# Altium schematic sheet MBoard_MotorControl.SchDoc
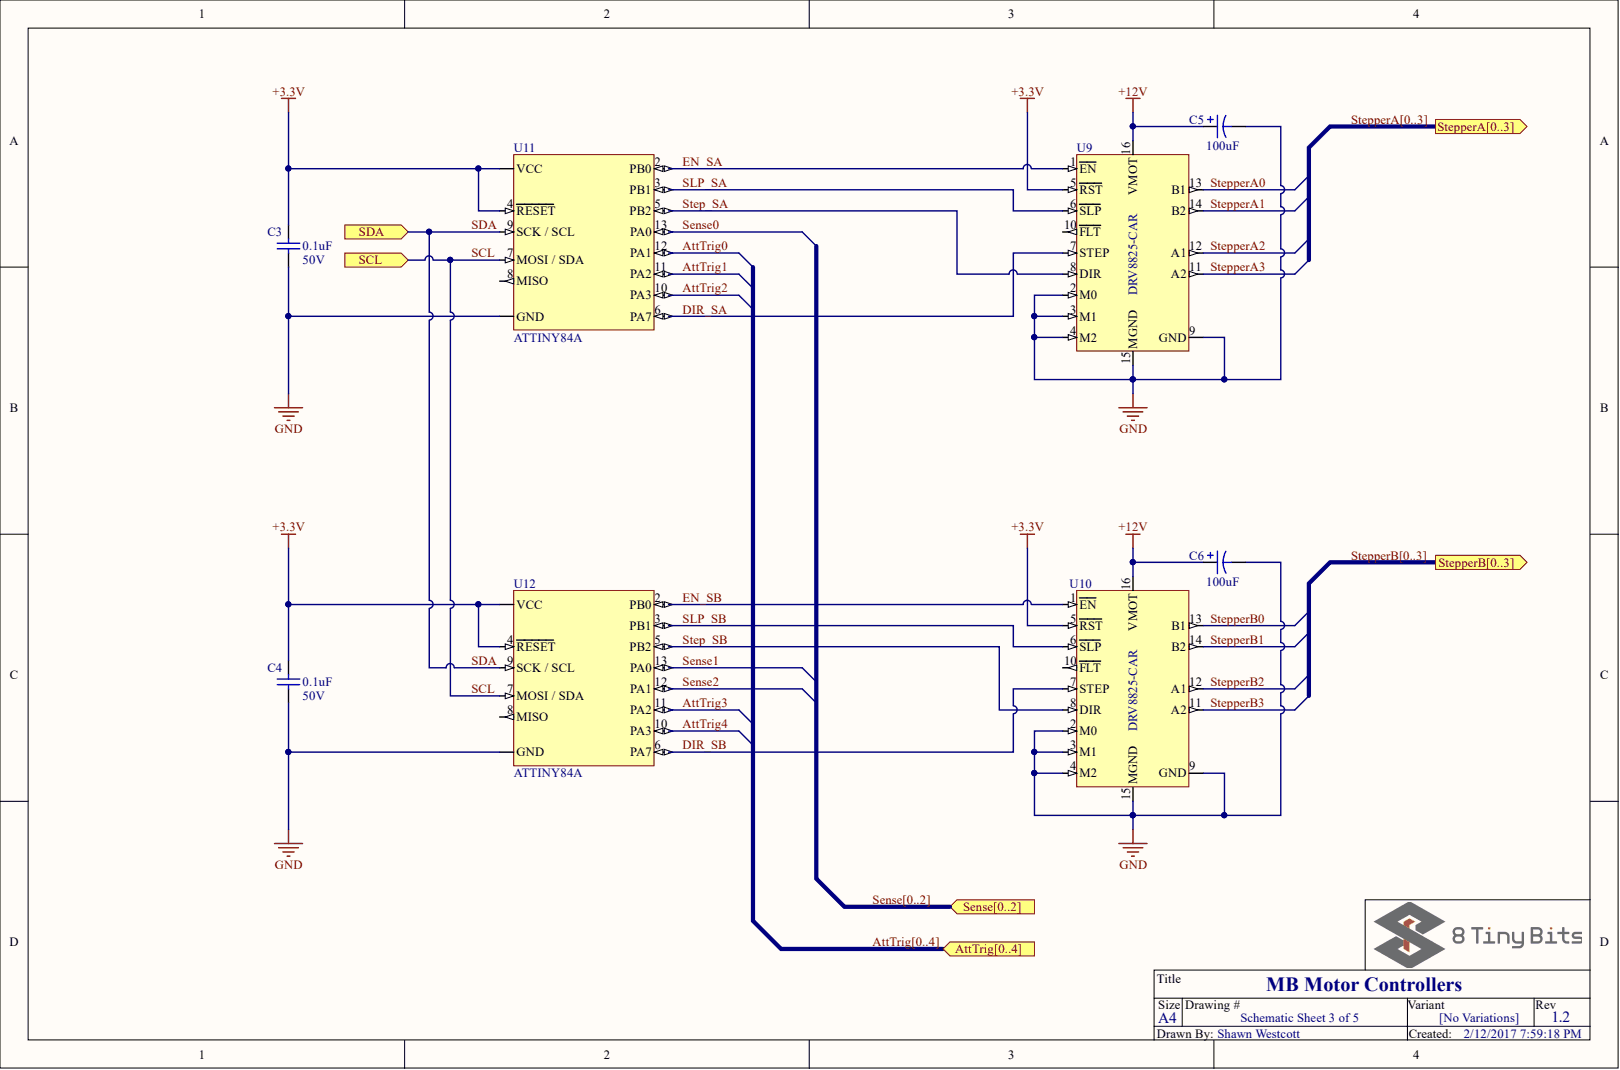
[diagram p0: MBoard_MotorControl.SchDoc]

## Parts
C3  value 0.1uF  Vmax: 50V  [CAP 1206]  (hidden comment: Cap)
C4  value 0.1uF  Vmax: 50V  [CAP 1206]  (hidden comment: Cap)
C5  value 100uF  [Cap, Alum, 150mil Radial, Polarized]  (hidden comment: Cap Pol1)
C6  value 100uF  [Cap, Alum, 150mil Radial, Polarized]  (hidden comment: Cap Pol1)
U9  DRV8825-CAR  [DRV8825-Carrier]
U10  DRV8825-CAR  [DRV8825-Carrier]
U11  ATTINY84A  [DIP14 S]
U12  ATTINY84A  [DIP14 S]

## Ports
ATTTRIG0, ATTTRIG1, ATTTRIG2, ATTTRIG3, ATTTRIG4, ATTTRIG[0..4], SCL, SDA, SENSE0, SENSE1, SENSE2, SENSE[0..2], STEPPERA0, STEPPERA1, STEPPERA2, STEPPERA3, STEPPERA[0..3], STEPPERB0, STEPPERB1, STEPPERB2, STEPPERB3, STEPPERB[0..3]

## Nets
+3.3V: C3.1 C4.1 U9.5(RST) U10.5(RST) U11.1(VCC) U11.4(RESET) U12.1(VCC) U12.4(RESET)
+12V: C5.1 C6.1 U9.16(VMOT) U10.16(VMOT)
GND: C3.2 C4.2 C5.2 C6.2 U9.2(M0) U9.3(M1) U9.4(M2) U9.9(GND) U9.15(MGND) U10.2(M0) U10.3(M1) U10.4(M2) U10.9(GND) U10.15(MGND) U11.14(GND) U12.14(GND)
ATTTRIG0: U11.12(PA1) ; port ATTTRIG[0..4]  [also labelled ATTTRIG]
ATTTRIG1: U11.11(PA2) ; port ATTTRIG[0..4]  [also labelled ATTTRIG]
ATTTRIG2: U11.10(PA3) ; port ATTTRIG[0..4]  [also labelled ATTTRIG]
ATTTRIG3: U12.11(PA2) ; port ATTTRIG[0..4]  [also labelled ATTTRIG]
ATTTRIG4: U12.10(PA3) ; port ATTTRIG[0..4]  [also labelled ATTTRIG]
DIR_SA: U9.7(STEP) U11.6(PA7)
DIR_SB: U10.7(STEP) U12.6(PA7)
EN_SA: U9.1(EN) U11.2(PB0)
EN_SB: U10.1(EN) U12.2(PB0)
SCL: U11.7(MOSI_/_SDA) U12.7(MOSI_/_SDA) ; port SCL
SDA: U11.9(SCK_/_SCL) U12.9(SCK_/_SCL) ; port SDA
SENSE0: U11.13(PA0) ; port SENSE[0..2]  [also labelled SENSE]
SENSE1: U12.13(PA0) ; port SENSE[0..2]  [also labelled SENSE]
SENSE2: U12.12(PA1) ; port SENSE[0..2]  [also labelled SENSE]
SLP_SA: U9.6(SLP) U11.3(PB1)
SLP_SB: U10.6(SLP) U12.3(PB1)
STEP_SA: U9.8(DIR) U11.5(PB2)
STEP_SB: U10.8(DIR) U12.5(PB2)
STEPPERA0: U9.13(B1) ; port STEPPERA[0..3]  [also labelled STEPPERA]
STEPPERA1: U9.14(B2) ; port STEPPERA[0..3]  [also labelled STEPPERA]
STEPPERA2: U9.12(A1) ; port STEPPERA[0..3]  [also labelled STEPPERA]
STEPPERA3: U9.11(A2) ; port STEPPERA[0..3]  [also labelled STEPPERA]
STEPPERB0: U10.13(B1) ; port STEPPERB[0..3]  [also labelled STEPPERB]
STEPPERB1: U10.14(B2) ; port STEPPERB[0..3]  [also labelled STEPPERB]
STEPPERB2: U10.12(A1) ; port STEPPERB[0..3]  [also labelled STEPPERB]
STEPPERB3: U10.11(A2) ; port STEPPERB[0..3]  [also labelled STEPPERB]

Not connected: U9 10(FLT); U10 10(FLT); U11 8(MISO); U12 8(MISO)

## Library data of the parts
C3 C4 = Cap: Capacitor; Component Kind: Standard; LatestRevisionDate: 17-Jul-2002; LatestRevisionNote: Re-released for DXP Platform.; Library Name: Miscellaneous Devices.IntLib; PackageDescription: Radial Cap, Thru-Hole; 2 Leads; 0.3 in Pin Spacing; PackageReference: RAD-0.3; Published: 8-Jun-2000; Publisher: Altium Limited
C5 C6 = Cap Pol1: Polarized Capacitor (Radial); Vmax: 50V; Component Kind: Standard; LatestRevisionDate: 17-Jul-2002; LatestRevisionNote: Re-released for DXP Platform.; Library Name: Miscellaneous Devices.IntLib; PackageDescription: Polarized Capacitor (Radial); 2 Leads; PackageReference: RB7.6-15; Published: 8-Jun-2000; Publisher: Altium Limited
U9 U10 = DRV8825-CARRIER: DRV8825 Stepper Motor Driver Carrier, High Current; Mfr1: Pololu; Mfr1 PN: 2133; Component Kind: Standard; Library Name: Custom_8TB.SchLib
U11 U12 = ATTINY84A: IC MCU 8BIT 8KB FLASH 14DIP; Mfr1: Microchip Technology; Mfr1 PN: ATTINY84A-PU; Component Kind: Standard; Library Name: Custom_8TB.SchLib
